# Altium schematic sheet 419-005-01-02_PG 07 - CV SoC - Config.SchDoc("419-005-01-02_PG 07 - CV SoC - Config")
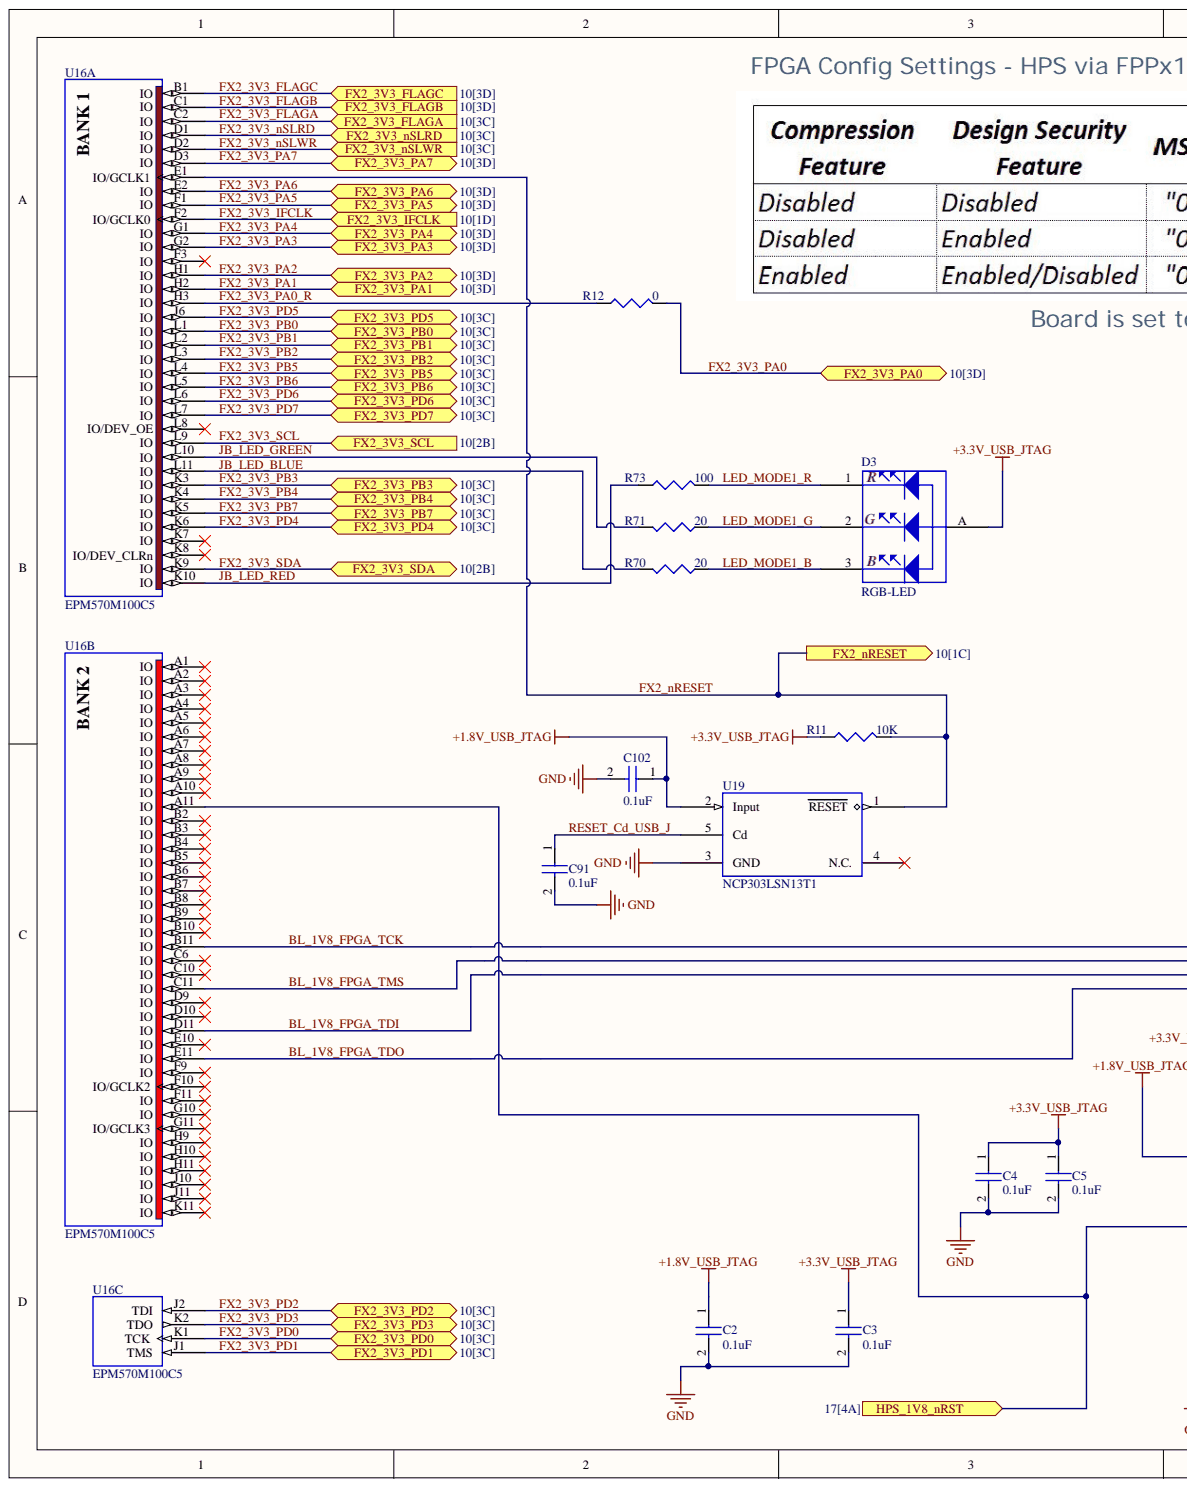
[diagram p0: 419-005-01-02_PG 07 - CV SoC - Config.SchDoc, left]
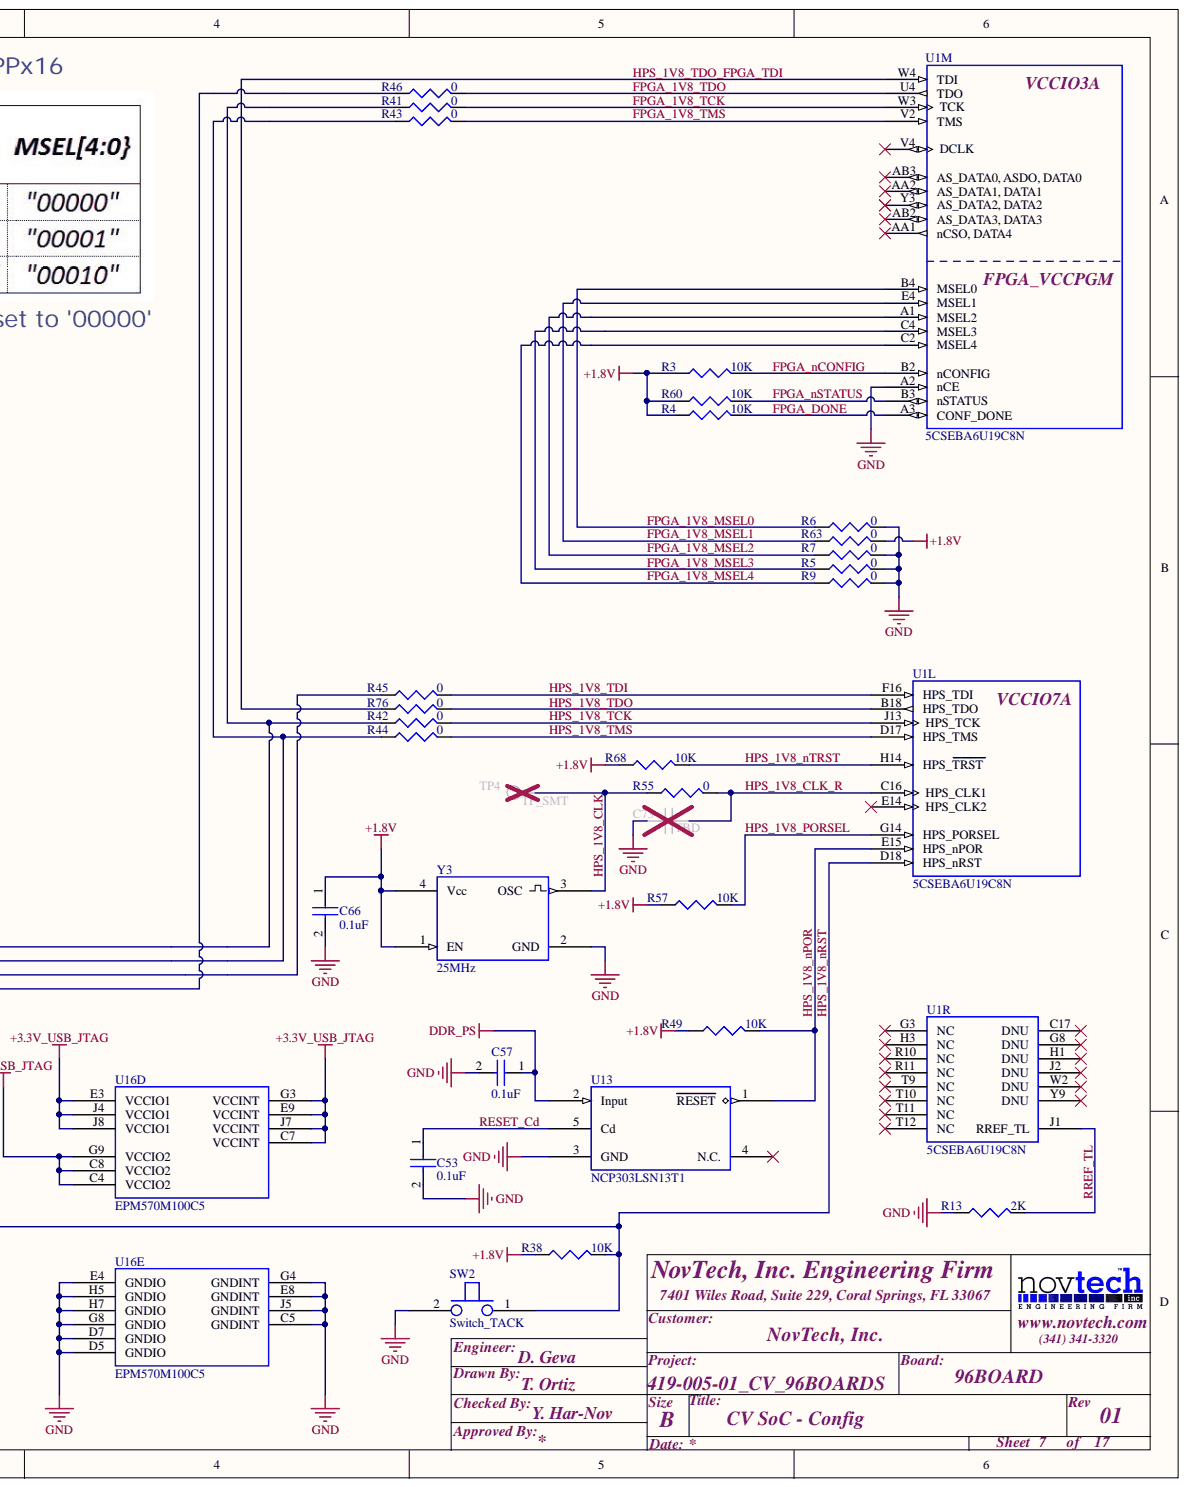
[diagram p1: 419-005-01-02_PG 07 - CV SoC - Config.SchDoc, right]

## Parts
C2  0.1uF  [0201_C]
C3  0.1uF  [0201_C]
C4  0.1uF  [0201_C]
C5  0.1uF  [0201_C]
C53  0.1uF  [0201_C]
C57  0.1uF  [0201_C]
C66  0.1uF  [0201_C]
C73  TBD  [0201_C]
C91  0.1uF  [0201_C]
C102  0.1uF  [0201_C]
D3  RGB-LED  [LED_RGB_1206]
R3  10K  [0201_R]
R4  10K  [0201_R]
R5  0  [0201_R]
R6  0  [0201_R]
R7  0  [0201_R]
R9  0  [0201_R]
R11  10K  [0201_R]
R12  0  [0201_R]
R13  2K  [0201_R]
R38  10K  [0201_R]
R41  0  [0201_R]
R42  0  [0201_R]
R43  0  [0201_R]
R44  0  [0201_R]
R45  0  [0201_R]
R46  0  [0201_R]
R49  10K  [0201_R]
R55  0  [0201_R]
R57  10K  [0201_R]
R60  10K  [0201_R]
R63  0  [0201_R]
R68  10K  [0201_R]
R70  20  [0402_R]
R71  20  [0402_R]
R73  100  [0201_R]
R76  0  [0201_R]
SW2  Switch_TACK  [KMR2]
TP4  TP_SMT  [TEST_POINT_SMT]
U1  5CSEBA6U19C8N  [BGA484C80P22X22_1900X1900X190]  (parts L,M,R)
U13  NCP303LSN13T1  [SOT23-5]
U16  EPM570M100C5  [MBGA100]  (parts A,B,C,D,E)
U19  NCP303LSN13T1  [SOT23-5]
Y3  25MHz  [OSC_SMT_2.5X2.0]

## Ports
FX2_3V3_FLAGA, FX2_3V3_FLAGB, FX2_3V3_FLAGC, FX2_3V3_IFCLK, FX2_3V3_NSLRD, FX2_3V3_NSLWR, FX2_3V3_PA0, FX2_3V3_PA1, FX2_3V3_PA2, FX2_3V3_PA3, FX2_3V3_PA4, FX2_3V3_PA5, FX2_3V3_PA6, FX2_3V3_PA7, FX2_3V3_PB0, FX2_3V3_PB1, FX2_3V3_PB2, FX2_3V3_PB3, FX2_3V3_PB4, FX2_3V3_PB5, FX2_3V3_PB6, FX2_3V3_PB7, FX2_3V3_PD0, FX2_3V3_PD1, FX2_3V3_PD2, FX2_3V3_PD3, FX2_3V3_PD4, FX2_3V3_PD5, FX2_3V3_PD6, FX2_3V3_PD7, FX2_3V3_SCL, FX2_3V3_SDA, FX2_NRESET, HPS_1V8_NRST

## Nets
+1.8V: C66.1 R3.1 R4.1 R38.1 R49.1 R57.1 R60.1 R63.2 R68.1 Y3.1(EN) Y3.4(Vcc)
+1.8V_USB_JTAG: C2.1 C102.1 U16.C4(VCCIO2) U16.C8(VCCIO2) U16.G9(VCCIO2) U19.2(Input)
+3.3V_USB_JTAG: C3.1 C4.1 C5.1 D3.A R11.1 U16.C7(VCCINT) U16.E3(VCCIO1) U16.E9(VCCINT) U16.G3(VCCINT) U16.J4(VCCIO1) U16.J7(VCCINT) U16.J8(VCCIO1)
DDR_PS: C57.1 U13.2(Input)
GND: C2.2 C3.2 C4.2 C5.2 C53.2 C57.2 C66.2 C73.2 C91.2 C102.2 R5.2 R6.2 R7.2 R9.2 R13.1 SW2.2 U1.A2(nCE) U13.3(GND) U16.C5(GNDINT) U16.D5(GNDIO) U16.D7(GNDIO) U16.E4(GNDIO) U16.E8(GNDINT) U16.G4(GNDINT) U16.G8(GNDIO) U16.H5(GNDIO) U16.H7(GNDIO) U16.J5(GNDINT) U19.3(GND) Y3.2(GND)
BL_1V8_FPGA_TCK: R41.1 R42.1 U16.B11(IO)
BL_1V8_FPGA_TDI: R45.1 U16.D11(IO)
BL_1V8_FPGA_TDO: R46.1 U16.E11(IO)
BL_1V8_FPGA_TMS: R43.1 R44.1 U16.C11(IO)
FPGA_1V8_MSEL0: R6.1 U1.B4(MSEL0)
FPGA_1V8_MSEL1: R63.1 U1.E4(MSEL1)
FPGA_1V8_MSEL2: R7.1 U1.A1(MSEL2)
FPGA_1V8_MSEL3: R5.1 U1.C4(MSEL3)
FPGA_1V8_MSEL4: R9.1 U1.C2(MSEL4)
FPGA_1V8_TCK: R41.2 U1.W3(TCK)
FPGA_1V8_TDO: R46.2 U1.U4(TDO)
FPGA_1V8_TMS: R43.2 U1.V2(TMS)
FPGA_DONE: R4.2 U1.A3(CONF_DONE)
FPGA_NCONFIG: R3.2 U1.B2(nCONFIG)
FPGA_NSTATUS: R60.2 U1.B3(nSTATUS)
FX2_3V3_FLAGA: U16.C2(IO) ; port FX2_3V3_FLAGA
FX2_3V3_FLAGB: U16.C1(IO) ; port FX2_3V3_FLAGB
FX2_3V3_FLAGC: U16.B1(IO) ; port FX2_3V3_FLAGC
FX2_3V3_IFCLK: U16.F2(IO/GCLK0) ; port FX2_3V3_IFCLK
FX2_3V3_NSLRD: U16.D1(IO) ; port FX2_3V3_NSLRD
FX2_3V3_NSLWR: U16.D2(IO) ; port FX2_3V3_NSLWR
FX2_3V3_PA0: R12.2 ; port FX2_3V3_PA0
FX2_3V3_PA0_R: R12.1 U16.H3(IO)
FX2_3V3_PA1: U16.H2(IO) ; port FX2_3V3_PA1
FX2_3V3_PA2: U16.H1(IO) ; port FX2_3V3_PA2
FX2_3V3_PA3: U16.G2(IO) ; port FX2_3V3_PA3
FX2_3V3_PA4: U16.G1(IO) ; port FX2_3V3_PA4
FX2_3V3_PA5: U16.F1(IO) ; port FX2_3V3_PA5
FX2_3V3_PA6: U16.E2(IO) ; port FX2_3V3_PA6
FX2_3V3_PA7: U16.D3(IO) ; port FX2_3V3_PA7
FX2_3V3_PB0: U16.L1(IO) ; port FX2_3V3_PB0
FX2_3V3_PB1: U16.L2(IO) ; port FX2_3V3_PB1
FX2_3V3_PB2: U16.L3(IO) ; port FX2_3V3_PB2
FX2_3V3_PB3: U16.K3(IO) ; port FX2_3V3_PB3
FX2_3V3_PB4: U16.K4(IO) ; port FX2_3V3_PB4
FX2_3V3_PB5: U16.L4(IO) ; port FX2_3V3_PB5
FX2_3V3_PB6: U16.L5(IO) ; port FX2_3V3_PB6
FX2_3V3_PB7: U16.K5(IO) ; port FX2_3V3_PB7
FX2_3V3_PD0: U16.K1(TCK) ; port FX2_3V3_PD0
FX2_3V3_PD1: U16.J1(TMS) ; port FX2_3V3_PD1
FX2_3V3_PD2: U16.J2(TDI) ; port FX2_3V3_PD2
FX2_3V3_PD3: U16.K2(TDO) ; port FX2_3V3_PD3
FX2_3V3_PD4: U16.K6(IO) ; port FX2_3V3_PD4
FX2_3V3_PD5: U16.J6(IO) ; port FX2_3V3_PD5
FX2_3V3_PD6: U16.L6(IO) ; port FX2_3V3_PD6
FX2_3V3_PD7: U16.L7(IO) ; port FX2_3V3_PD7
FX2_3V3_SCL: U16.L9(IO) ; port FX2_3V3_SCL
FX2_3V3_SDA: U16.K9(IO) ; port FX2_3V3_SDA
FX2_NRESET: R11.2 U16.E1(IO/GCLK1) U19.1(RESET) ; port FX2_NRESET
HPS_1V8_CLK: R55.1 TP4.1 Y3.3
HPS_1V8_CLK_R: C73.1 R55.2 U1.C16(HPS_CLK1)
HPS_1V8_NPOR: R49.2 U1.E15(HPS_nPOR) U13.1(RESET)
HPS_1V8_NRST: R38.2 SW2.1 U1.D18(HPS_nRST) U16.A11(IO) ; port HPS_1V8_NRST
HPS_1V8_NTRST: R68.2 U1.H14(HPS_TRST)
HPS_1V8_PORSEL: R57.2 U1.G14(HPS_PORSEL)
HPS_1V8_TCK: R42.2 U1.J13(HPS_TCK)
HPS_1V8_TDI: R45.2 U1.F16(HPS_TDI)
HPS_1V8_TDO: R76.2 U1.B18(HPS_TDO)
HPS_1V8_TDO_FPGA_TDI: R76.1 U1.W4(TDI)
HPS_1V8_TMS: R44.2 U1.D17(HPS_TMS)
JB_LED_BLUE: R70.2 U16.L11(IO)
JB_LED_GREEN: R71.2 U16.L10(IO)
JB_LED_RED: R73.1 U16.K10(IO)
LED_MODE1_B: D3.3(B) R70.1
LED_MODE1_G: D3.2 R71.1
LED_MODE1_R: D3.1 R73.2
RESET_CD: C53.1 U13.5(Cd)
RESET_CD_USB_J: C91.1 U19.5(Cd)
RREF_TL: R13.2 U1.J1(RREF_TL)

Not connected: U1 AA1(nCSO,_DATA4) AA2(AS_DATA1,_DATA1) AB2(AS_DATA3,_DATA3) AB3(AS_DATA0,_ASDO,_DATA0) C17(DNU) E14(HPS_CLK2) G3(NC) G8(DNU) H1(DNU) H3(NC) J2(DNU) R10(NC) R11(NC) T9(NC) T10(NC) T11(NC) T12(NC) V4(DCLK) W2(DNU) Y3(AS_DATA2,_DATA2) Y9(DNU); U13 4(N.C.); U16 A1(IO) A2(IO) A3(IO) A4(IO) A5(IO) A6(IO) A7(IO) A8(IO) A9(IO) A10(IO) B2(IO) B3(IO) B4(IO) B5(IO) B6(IO) B7(IO) B8(IO) B9(IO) B10(IO) C6(IO) C10(IO) D9(IO) D10(IO) E10(IO) F3(IO) F9(IO) F10(IO/GCLK2) F11(IO) G10(IO) G11(IO/GCLK3) H9(IO) H10(IO) H11(IO) J10(IO) J11(IO) K7(IO) K8(IO/DEV_CLRn) K11(IO) L8(IO/DEV_OE); U19 4(N.C.)

## Library data of the parts
C2-C5 C53 C57 C66 C73 C91 C102 = CAP_0201: Capasitor 0201 footprint; Component Kind: Standard; Library Name: PASSIVES.SchLib
D3 = LED_RGB: PART: LTST-S310F2KT; MFG: Lite-On; TYPE: SMT; Component Kind: Standard; DigiKey: Mouser: 859-LTST-S310F2KT; Library Name: PASSIVES.SchLib; RoHS: Yes
R3 R4 R60 = RESISOTR 0201: Resistor 0201 footprint; PART: ERJ-1GEF1002C; MFG: Panasonic; Component Kind: Standard; Digikey: P10.0KABCT-ND; Library Name: PASSIVES.SchLib; RoHS: Yes; TYPE: SMT
R5-R7 R9 R11 R12 R38 R41-R46 R49 R55 R57 R63 R68 R76 = RESISOTR 0201: Resistor 0201 footprint; MFG: Panasonic; Component Kind: Standard; Library Name: PASSIVES.SchLib; RoHS: Yes; TYPE: SMT
R13 = RESISOTR 0201: Resistor 0201 footprint; PART: ERJ-1GEF2001C; MFG: Panasonic; Component Kind: Standard; Digikey: P2.00KABCT-ND; Library Name: PASSIVES.SchLib; RoHS: Yes; TYPE: SMT
R70 R71 = RESISOTR 0402: Resistor 0402 footprint; PART: RC0402FR-0720RL; MFG: Yageo; TEMP: -55°C to +155°C; Component Kind: Standard; DigiKey: 311-20LRCT-ND; Library Name: PASSIVES.SchLib; RoHS: Yes; TYPE: SMT
R73 = RESISOTR 0201: Resistor 0201 footprint; PART: RMCF0201FT100R; MFG: Stackpole; TEMP: -55°C t0 +125°C; Component Kind: Standard; DigiKey: RMCF0201FT100RCT-ND; Library Name: PASSIVES.SchLib; RoHS: Yes; TYPE: SMT
SW2 = Switch_TACK: Switch, 2 contacts; PART: KMR241NG ULC LFS; MFG: C&K; TEMP: -40°C to +85°C; Component Kind: Standard; DigiKey: CKN10285CT-ND; Library Name: CONNECTORS_MECHANICAL.SchLib; RoHS: Yes; TYPE: SMT
TP4 = TEST_POINT: PCB Test Point; Component Kind: Standard; Library Name: PASSIVES.SchLib
U1 = 5CSEBA6U19C8N: Cyclone V SE Family FPGA, 1.07 to 1.13 V Supply, 66 I/O Pins, 6 PLLs, 484-Pin UBGA, Speed Grade 7, Commercial Grade, RoHS Compliant; Manufacturer: Altera; Logic Elements (LE) (K): 110; Speed Grade: 8; Code_JEDEC: MO-275; Component Kind: Standard; ComponentLink1Description: Manufacturer URL; ComponentLink1URL: http://www.altera.com; ComponentLink2Description: Datasheet; ComponentLink2URL: http://www.altera.com/literature/hb/cyclone-v/cv_51002.pdf; ComponentLink3Description: Package Specification; ComponentLink3URL: http://www.altera.com/devicepackaging/04R00436-01.pdf; ComponentLink4Description: Device Handbook URL; ComponentLink4URL: http://www.altera.com/literature/hb/cyclone-v/cyclone5_handbook.pdf; ComponentLink5Description: Device Pin-Out URL; ComponentLink5URL: http://www.altera.com/literature/dp/cyclone-v/5CSEBA6.xls; ComponentLink6Description: Product URL; ComponentLink6URL: http://www.buyaltera.com/scripts/partsearch.dll?Detail&name=5CSEBA6U19C8N-ND; DatasheetVersion: Rev. 3.4; Device Group: 5CSEBA6; Library Name: IC_SchLib.SCHLIB; Mounting Technology: Surface Mount; NexusDeviceID: 5CSEBA6U19C8N; PackageDescription: 484-Pin Ultra FineLine Ball-Grid Array (UBGA), Body 19 x 19 mm, Pitch 0.8 mm; PackageReference: UBGA484_A-190; PackageVersion: Rev. 1.0, 12/2011; PartNumber: 5CSEBA6U19C8N; RoHS: RoHS Compliant; Subcategory: Cyclone V SE; Supplier 1: Digi-Key; Supplier 2: Mouser; Supplier Part Number 1: 5CSEBA6U19C8N-ND; Supplier Part Number 2: 989-5CSEBA6U19C8N; Voltage Supply: 1.07 to 1.13 V
U13 U19 = NCP303LSN13T1: Voltage Detector Series with Programmable Delay; Marking: SDA; MFG: On Semi; TEMP: -40°C to +125°C; Component Kind: Standard; Library Name: IC_SchLib.SCHLIB; PART: NCP303LSN13T1; RoHS: Yes; TYPE: SMT
U16 = EPM570M100C5: MAX II 3.3/2.5V CPLD, 76 IOs, 570 Logic Elements, 100-Pin Micro Fineline BGA, Commercial Temperature, Speed Grade 5; Component Kind: Standard; Library Name: IC_SchLib.SCHLIB
Y3 = OSCILLATOR: PART: ASDMB-25.000MHZ-LC-T; MFG: Abracon; TEMP: -40°C to +85°C; Component Kind: Standard; DigiKey: 535-11730-1-ND; Library Name: PASSIVES.SchLib; RoHS: Yes; TYPE: SMT
